# Altium schematic sheet Gecko.SchDoc
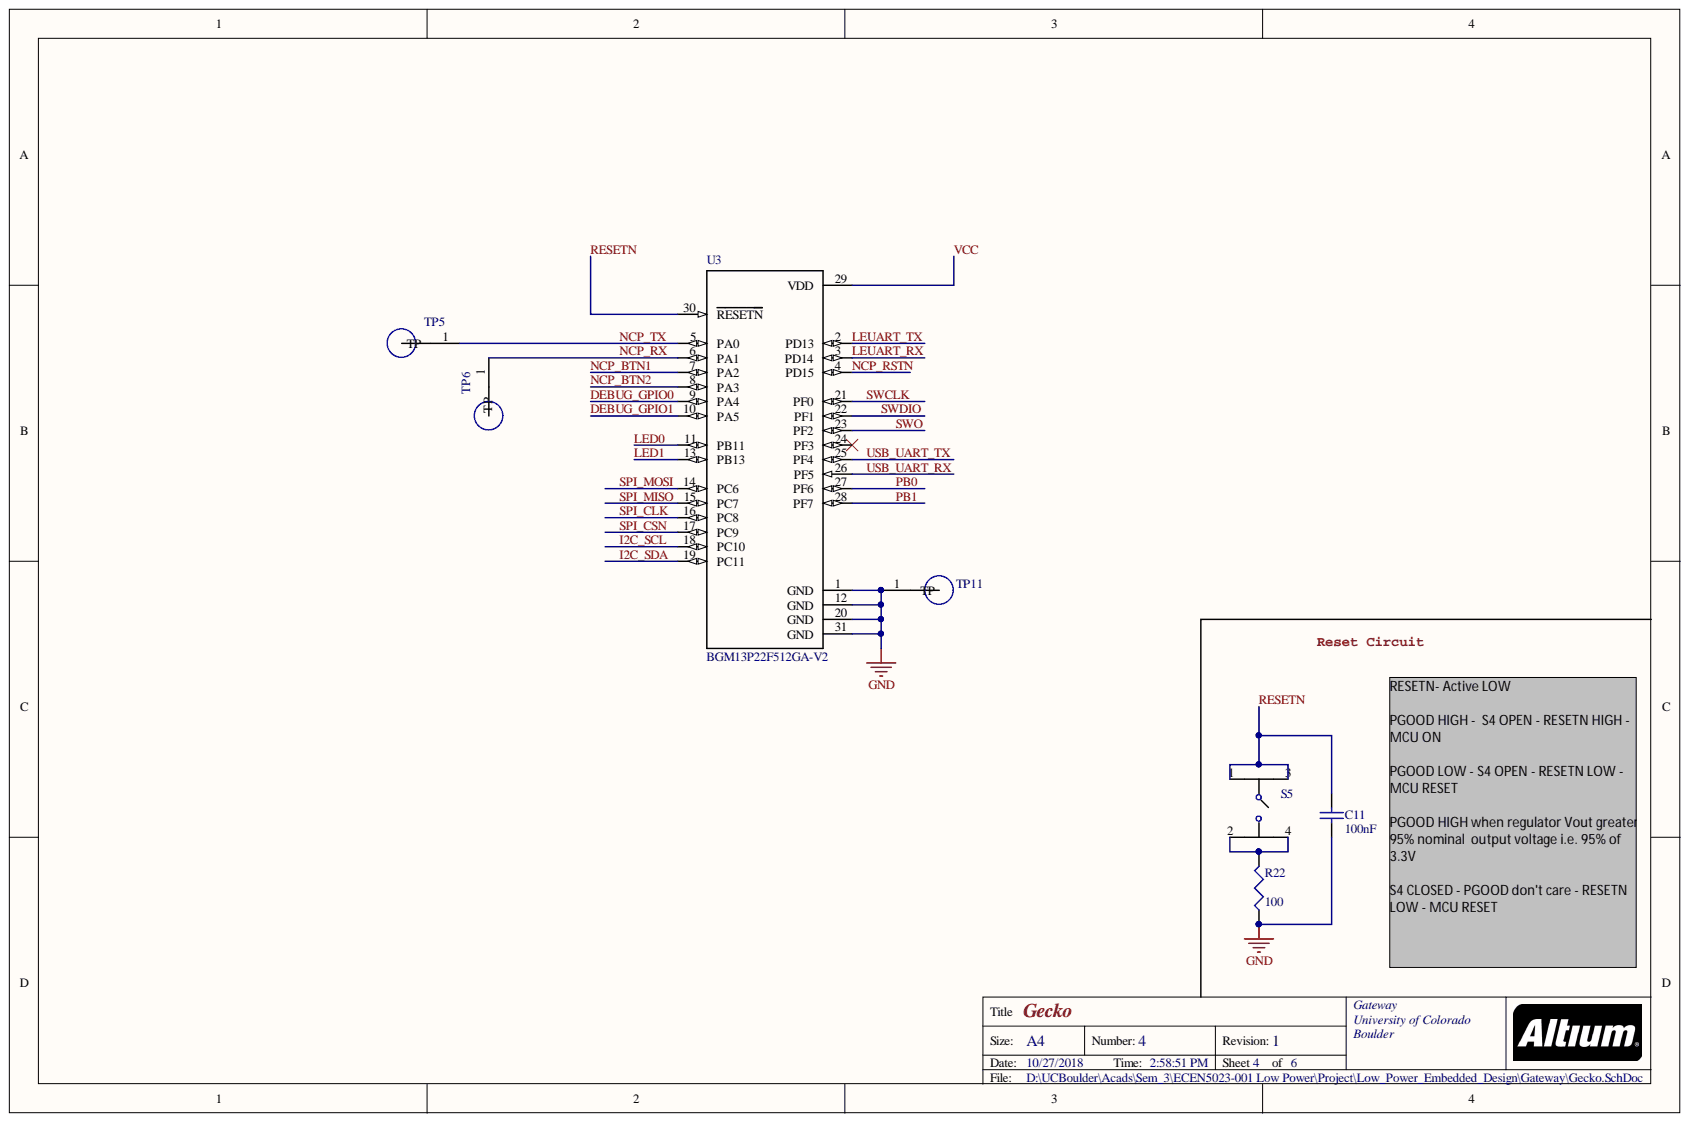
[diagram p0: Gecko.SchDoc]

## Parts
C11  value 100nF  [1608[0603]]  (hidden comment: 0603YC104MAT4A)
R22  value 100  [1608[0603]]  (hidden comment: CRCW0603100RJNEAC)
S5  430182043816  [430182043816]  (value not drawn)
TP5  5019  [TP380X203]  (value not drawn)
TP6  5019  [TP380X203]  (value not drawn)
TP11  5019  [TP380X203]  (value not drawn)
U3  BGM13P22F512GA-V2  [MODULE_BGM13P22F512GA-V2]

## Nets
GND: C11.2 R22.1 TP11.1 U3.1(GND) U3.12(GND) U3.20(GND) U3.31(GND)
DEBUG_GPIO0: U3.9(PA4)
DEBUG_GPIO1: U3.10(PA5)
I2C_SCL: U3.18(PC10)
I2C_SDA: U3.19(PC11)
LED0: U3.11(PB11)
LED1: U3.13(PB13)
LEUART_RX: U3.3(PD14)
LEUART_TX: U3.2(PD13)
NCP_BTN1: U3.7(PA2)
NCP_BTN2: U3.8(PA3)
NCP_RSTN: U3.4(PD15)
NCP_RX: TP6.1 U3.6(PA1)
NCP_TX: TP5.1 U3.5(PA0)
PB0: U3.27(PF6)
PB1: U3.28(PF7)
RESETN: C11.1 S5.1 S5.3 U3.30(RESETN)
SPI_CLK: U3.16(PC8)
SPI_CSN: U3.17(PC9)
SPI_MISO: U3.15(PC7)
SPI_MOSI: U3.14(PC6)
SWCLK: U3.21(PF0)
SWDIO: U3.22(PF1)
SWO: U3.23(PF2)
USB_UART_RX: U3.26(PF5)
USB_UART_TX: U3.25(PF4)
VCC: U3.29(VDD)
NetR22_2: R22.2 S5.2 S5.4

Not connected: U3 24(PF3)

## Library data of the parts
C11 = Cap: CAP CER 0.1UF 16V X7R 0603; Manufacturer: AVX Corporation; Component Kind: Standard; ComponentLink1Description: Digi-Key Link; ComponentLink1URL: https://www.digikey.com/products/en/capacitors/ceramic-capacitors/60?k=capacitor&k=&pkeyword=capacitor&pv2049=u0.1%C2%B5F&sf=0&FV=c0005%2C1c0002%2C380009%2C400005%2C1f140000%2Cffe0003c&quantity=&ColumnSort=0&page=1&stock=1&pageSize=25; Digi-Key Part Number: 478-7617-1-ND; LatestRevisionDate: 17-Jul-2002; LatestRevisionNote: Re-released for DXP Platform.; Library Name: Miscellaneous Devices.IntLib; Manufacturer Part Number: 0603YC104MAT4A; PackageDescription: Radial Cap, Thru-Hole; 2 Leads; 0.3 in Pin Spacing; PackageReference: RAD-0.3; Published: 8-Jun-2000; Signal Integrity: Cap; Simulation: CAP; Publisher: Altium Limited
R22 = Res3: RES SMD 100 OHM 5% 1/10W 0603; Manufacturer: Vishay Dale; Component Kind: Standard; ComponentLink1Description: Digi-Key Link; ComponentLink1URL: https://www.digikey.com/product-detail/en/vishay-dale/CRCW0603100RJNEAC/541-4059-1-ND/7928742; Digi-Key Part Number: 541-4059-1-ND; LatestRevisionDate: 29-May-2009; LatestRevisionNote: IPC-7351 Footprint Added.; Library Name: Miscellaneous Devices.IntLib; Manufacturer Part Number: CRCW0603100RJNEAC; PackageDescription: Chip Resistor; PackageReference: J1-0603; Published: 8-Jun-2000; Signal Integrity: Res; Simulation: RESISTOR; Publisher: Altium Limited
S5 = 430182043816: SWITCH TACTILE SPST-NO 0.05A 12V; Manufacturer: Wurth Electronics; Component Kind: Standard; ComponentLink1Description: Digi-Key Link; ComponentLink1URL: https://www.digikey.com/products/en/switches/tactile-switches/197?k=430+182+043+816&k=&pkeyword=430+182+043+816&pv7=2&sf=0&quantity=&ColumnSort=0&page=1&pageSize=25; Digi-Key Part Number: 732-7004-1-ND; Library Name: 430182043816.SchLib; Manufacturer Part Number: 430182043816
TP5 TP6 TP11 = 5019: PC TEST POINT MINIATURE; Manufacturer: Keystone Electronics; MF: Keystone; Component Kind: Standard; ComponentLink1Description: Digi-Key Link; ComponentLink1URL: https://www.digikey.com/product-detail/en/keystone-electronics/5019/36-5019CT-ND/3907344?utm_source=snapeda&utm_medium=aggregator&utm_campaign=symbol; Digi-Key_Part_Number: 36-5019CT-ND; Digi-Key_Purchase_URL: https://www.digikey.com/product-detail/en/keystone-electronics/5019/36-5019CT-ND/3907344?utm_source=snapeda&utm_medium=aggregator&utm_campaign=symbol; Digi-Key Part Number: 36-5019CT-ND; Library Name: 5019.IntLib; Manufacturer Part Number: 5019; MP: 5019; Package: 2010 Keystone; SnapEDA_Link: https://www.snapeda.com/parts/5019/Keystone%20Electronics/view-part/?ref=snap
U3 = BGM13P22F512GA-V2: EFR32BG13 Bluetooth 5.0 Module, 512kB Flash, +8dbm; Manufacturer: Silicon Labs; Availability: Available; Component Kind: Standard; ComponentLink1Description: Digi-Key Link; ComponentLink1URL: https://www.digikey.com/product-detail/en/silicon-labs/BGM13P32F512GA-V2R/336-5465-1-ND/9324917; Digi-Key Part Number: 336-5465-1-ND; Library Name: BGM13P22F512GA-V2.SchLib; Manufacturer Part Number: BGM13P22F512GA-V2; Package: Module Silicon Labs; Price: None; SnapEDA_Link: https://www.snapeda.com/parts/BGM13P22F512GA-V2/Silicon%20Labs/view-part/?ref=snap
